# Altium schematic sheet iSEB_PLC_2401.SchDoc
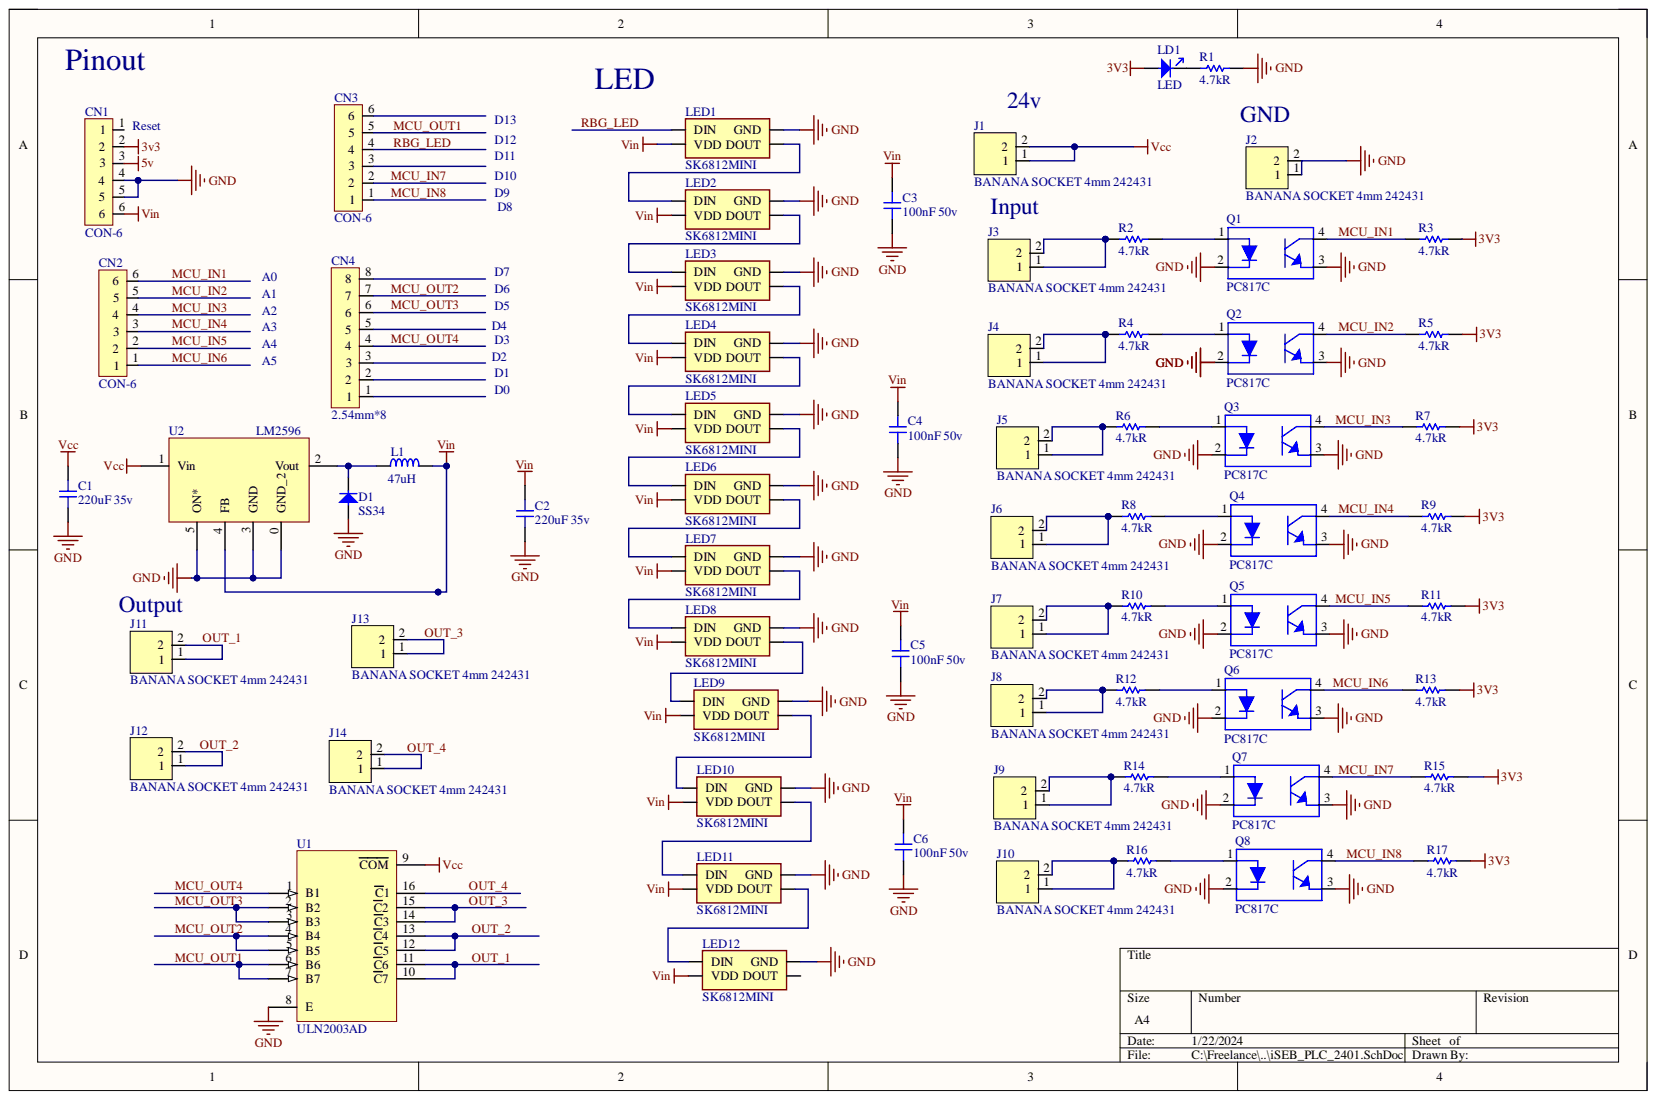
[diagram p0: iSEB_PLC_2401.SchDoc]

## Parts
C1  220uF 35v  [CAP-8x16x3.5MM]
C2  220uF 35v  [CAP-8x16x3.5MM]
C3  100nF 50v  [0603C]
C4  100nF 50v  [0603C]
C5  100nF 50v  [0603C]
C6  100nF 50v  [0603C]
CN1  CON-6  [PIN-6]
CN2  CON-6  [PIN-6]
CN3  CON-6  [PIN-6]
CN4  2.54mm*8  [PIN-8]
D1  SS34  [DO-214AC]
J1  BANANA SOCKET 4mm 242431  [BANANA SOKCT 4mm 242431-RED]
J2  BANANA SOCKET 4mm 242431  [BANANA SOKCT 4mm 242431-BLUE]
J3  BANANA SOCKET 4mm 242431  [BANANA SOKCT 4mm 242431-BLACK]
J4  BANANA SOCKET 4mm 242431  [BANANA SOKCT 4mm 242431-BLACK]
J5  BANANA SOCKET 4mm 242431  [BANANA SOKCT 4mm 242431-BLACK]
J6  BANANA SOCKET 4mm 242431  [BANANA SOKCT 4mm 242431-BLACK]
J7  BANANA SOCKET 4mm 242431  [BANANA SOKCT 4mm 242431-BLACK]
J8  BANANA SOCKET 4mm 242431  [BANANA SOKCT 4mm 242431-BLACK]
J9  BANANA SOCKET 4mm 242431  [BANANA SOKCT 4mm 242431-BLACK]
J10  BANANA SOCKET 4mm 242431  [BANANA SOKCT 4mm 242431-BLACK]
J11  BANANA SOCKET 4mm 242431  [BANANA SOKCT 4mm 242431-BLACK]
J12  BANANA SOCKET 4mm 242431  [BANANA SOKCT 4mm 242431-BLACK]
J13  BANANA SOCKET 4mm 242431  [BANANA SOKCT 4mm 242431-BLACK]
J14  BANANA SOCKET 4mm 242431  [BANANA SOKCT 4mm 242431-BLACK]
L1  47uH  [IND-7X7]
LD1  LED  [led0603]
LED1  SK6812MINI  [SK6812MINI]
LED2  SK6812MINI  [SK6812MINI]
LED3  SK6812MINI  [SK6812MINI]
LED4  SK6812MINI  [SK6812MINI]
LED5  SK6812MINI  [SK6812MINI]
LED6  SK6812MINI  [SK6812MINI]
LED7  SK6812MINI  [SK6812MINI]
LED8  SK6812MINI  [SK6812MINI]
LED9  SK6812MINI  [SK6812MINI]
LED10  SK6812MINI  [SK6812MINI]
LED11  SK6812MINI  [SK6812MINI]
LED12  SK6812MINI  [SK6812MINI]
Q1  PC817C  [DIP-4]
Q2  PC817C  [DIP-4]
Q3  PC817C  [DIP-4]
Q4  PC817C  [DIP-4]
Q5  PC817C  [DIP-4]
Q6  PC817C  [DIP-4]
Q7  PC817C  [DIP-4]
Q8  PC817C  [DIP-4]
R1  4.7kR  [0603]
R2  4.7kR  [0603]
R3  4.7kR  [0603]
R4  4.7kR  [0603]
R5  4.7kR  [0603]
R6  4.7kR  [0603]
R7  4.7kR  [0603]
R8  4.7kR  [0603]
R9  4.7kR  [0603]
R10  4.7kR  [0603]
R11  4.7kR  [0603]
R12  4.7kR  [0603]
R13  4.7kR  [0603]
R14  4.7kR  [0603]
R15  4.7kR  [0603]
R16  4.7kR  [0603]
R17  4.7kR  [0603]
U1  ULN2003AD  [D016_N]
U2  LM2596  [TO-263-5PIN]

## Nets
3v3: CN1.2 LD1.1 R3.2 R5.2 R7.2 R9.2 R11.2 R13.2 R15.2 R17.2
5v: CN1.3
GND: C1.2 C2.2 C3.2 C4.2 C5.2 C6.2 CN1.4 CN1.5 D1.A J2.1 J2.2 LED1.VSS(GND) LED2.VSS(GND) LED3.VSS(GND) LED4.VSS(GND) LED5.VSS(GND) LED6.VSS(GND) LED7.VSS(GND) LED8.VSS(GND) LED9.VSS(GND) LED10.VSS(GND) LED11.VSS(GND) LED12.VSS(GND) Q1.2 Q1.3 Q2.2 Q2.3 Q3.2 Q3.3 Q4.2 Q4.3 Q5.2 Q5.3 Q6.2 Q6.3 Q7.2 Q7.3 Q8.2 Q8.3 R1.2 U1.8(E) U2.0(GND_2) U2.3(GND) U2.5(ON*)
Vcc: C1.1 J1.1 J1.2 U1.9(COM) U2.1(Vin)
Vin: C2.1 C3.1 C4.1 C5.1 C6.1 CN1.6 L1.2 LED1.VDD LED2.VDD LED3.VDD LED4.VDD LED5.VDD LED6.VDD LED7.VDD LED8.VDD LED9.VDD LED10.VDD LED11.VDD LED12.VDD U2.4(FB)
MCU_IN1: CN2.6 Q1.4 R3.1
MCU_IN2: CN2.5 Q2.4 R5.1
MCU_IN3: CN2.4 Q3.4 R7.1
MCU_IN4: CN2.3 Q4.4 R9.1
MCU_IN5: CN2.2 Q5.4 R11.1
MCU_IN6: CN2.1 Q6.4 R13.1
MCU_IN7: CN3.2 Q7.4 R15.1
MCU_IN8: CN3.1 Q8.4 R17.1
MCU_OUT1: CN3.5 U1.6(B6) U1.7(B7)
MCU_OUT2: CN4.7 U1.4(B4) U1.5(B5)
MCU_OUT3: CN4.6 U1.2(B2) U1.3(B3)
MCU_OUT4: CN4.4 U1.1(B1)
OUT_1: J11.1 J11.2 U1.10(C7) U1.11(C6)
OUT_2: J12.1 J12.2 U1.12(C5) U1.13(C4)
OUT_3: J13.1 J13.2 U1.14(C3) U1.15(C2)
OUT_4: J14.1 J14.2 U1.16(C1)
RBG_LED: CN3.4 LED1.DIN
NetD1_K: D1.K L1.1 U2.2(Vout)
NetJ3_1: J3.1 J3.2 R2.1
NetJ4_1: J4.1 J4.2 R4.1
NetJ5_1: J5.1 J5.2 R6.1
NetJ6_1: J6.1 J6.2 R8.1
NetJ7_1: J7.1 J7.2 R10.1
NetJ8_1: J8.1 J8.2 R12.1
NetJ9_1: J9.1 J9.2 R14.1
NetJ10_1: J10.1 J10.2 R16.1
NetLD1_2: LD1.2 R1.1
NetLED1_DOUT: LED1.DOUT LED2.DIN
NetLED2_DOUT: LED2.DOUT LED3.DIN
NetLED3_DOUT: LED3.DOUT LED4.DIN
NetLED4_DOUT: LED4.DOUT LED5.DIN
NetLED5_DOUT: LED5.DOUT LED6.DIN
NetLED6_DOUT: LED6.DOUT LED7.DIN
NetLED7_DOUT: LED7.DOUT LED8.DIN
NetLED8_DOUT: LED8.DOUT LED9.DIN
NetLED9_DOUT: LED9.DOUT LED10.DIN
NetLED10_DOUT: LED10.DOUT LED11.DIN
NetLED11_DOUT: LED11.DOUT LED12.DIN
NetQ1_1: Q1.1 R2.2
NetQ2_1: Q2.1 R4.2
NetQ3_1: Q3.1 R6.2
NetQ4_1: Q4.1 R8.2
NetQ5_1: Q5.1 R10.2
NetQ6_1: Q6.1 R12.2
NetQ7_1: Q7.1 R14.2
NetQ8_1: Q8.1 R16.2

Not connected: CN1 1; CN3 3 6; CN4 1 2 3 5 8; LED12 DOUT

## Library data of the parts
C1 C2 = CAP: 直插电解电容 35V 220uF ±20% 体积8*12MM; Manufacturer: CX(承兴); PartNumber: 220uF 35V 8*12; Component Kind: Standard; Library Name: BingRan_2019.SchLib
C3-C6 = CAP: 0603贴片电容50V 100NF ±10% X7R CL10B104KB8NNNC; Manufacturer: Samsung Electro-Mechanics; Component Kind: Standard; PartNumber: CL10B104KB8NNNC; Library Name: BingRan_2019.SchLib
CN1-CN3 = CON-6: 排针 间距2.54mm 6Pins(1x6) 黄铜 镀金 直插; Manufacturer: CJT; PartNumber: A2541WV-6P; Component Kind: Standard; Library Name: BingRan_2019.SchLib
CN4 = CON-8: 排针 间距2.54mm 8Pins(1x8) 黄铜 镀金 直插; Manufacturer: CJT; PartNumber: A2541WV-8P; Component Kind: Standard; Library Name: BingRan_2019.SchLib
D1 = DIODE: Component Kind: Standard; Description: SS34; PartNumber: SS34; Library Name: BingRan_2019.SchLib
J1 = BANANA SOCKET 4mm 242431: Banana Socket, 20a, 4mm, Pcb, Red; Manufacturer: Multicomp; PartNumber: 24.243.1; Component Kind: Standard; Library Name: BingRan_2019.SchLib
J2 = BANANA SOCKET 4mm 242431: Banana Socket, 20a, 4mm, Pcb, Blue; Manufacturer: Multicomp; PartNumber: 24.243.1; Component Kind: Standard; Library Name: BingRan_2019.SchLib
J3-J14 = BANANA SOCKET 4mm 242431: Banana Socket, 20a, 4mm, Pcb, Black; Manufacturer: Multicomp; PartNumber: 24.243.1; Component Kind: Standard; Library Name: BingRan_2019.SchLib
L1 = INDUCTOR: CDRH74R 7*7*4MM 47uH 470 屏蔽电感/贴片功率电感; Manufacturer: Sunlord; Component Kind: Standard; PartNumber: CDRH74R; Library Name: BingRan_2019.SchLib
LD1 = LED: Manufacturer: XINGLIGHT(成兴光); PartNumber: XL-1608SURC-06; Component Kind: Standard; Library Name: BingRan_2019.SchLib
LED1-LED12 = SK6812MINI: INTEGRATED LIGHT SOURCE INTELLIGENT CONTROL OF CHIP-ON-TOP SMD TYPE LED; Manufacturer: DONGGUANG OPSCO OPTOELECTRONICS CO., LTD; Component Kind: Standard; PartNumber: SK6812MINI; Library Name: BingRan_2019.SchLib
Q1-Q8 = PC817C: Optoisolator Transistor Output 5000Vrms 1 Channel 4-DIP; Manufacturer: Taiwan Semiconductor; Component Kind: Standard; PartNumber: PC817C; Library Name: BingRan_2019.SchLib
R1-R17 = Resistor_ST: 贴片电阻 4.7KΩ ±1% 1/10W ±100ppm/℃ 0603; Manufacturer: YAGEO; PartNumber: RC0603FR-074K7L; Value: NA; Component Kind: Standard; Library Name: Miscellaneous Devices.IntLib
U1 = ULN2003AD: IC PWR RELAY 7NPN 1:1 16SO; Manufacturer: ON; PartNumber: ULN2003ADR2G; Component Kind: Standard; Library Name: TI Interface Darlington Driver.IntLib; PCB3D: D016
U2 = LM2596: 固定电压5V 3A 150kHz Vin(Max)=40V 降压开关调节器; PartNumber: LM2596R-5.0; Component Kind: Standard; Library Name: BingRan_2019.SchLib
